# Altium schematic sheet CLK_Gen.SchDoc(CLK_Gen)
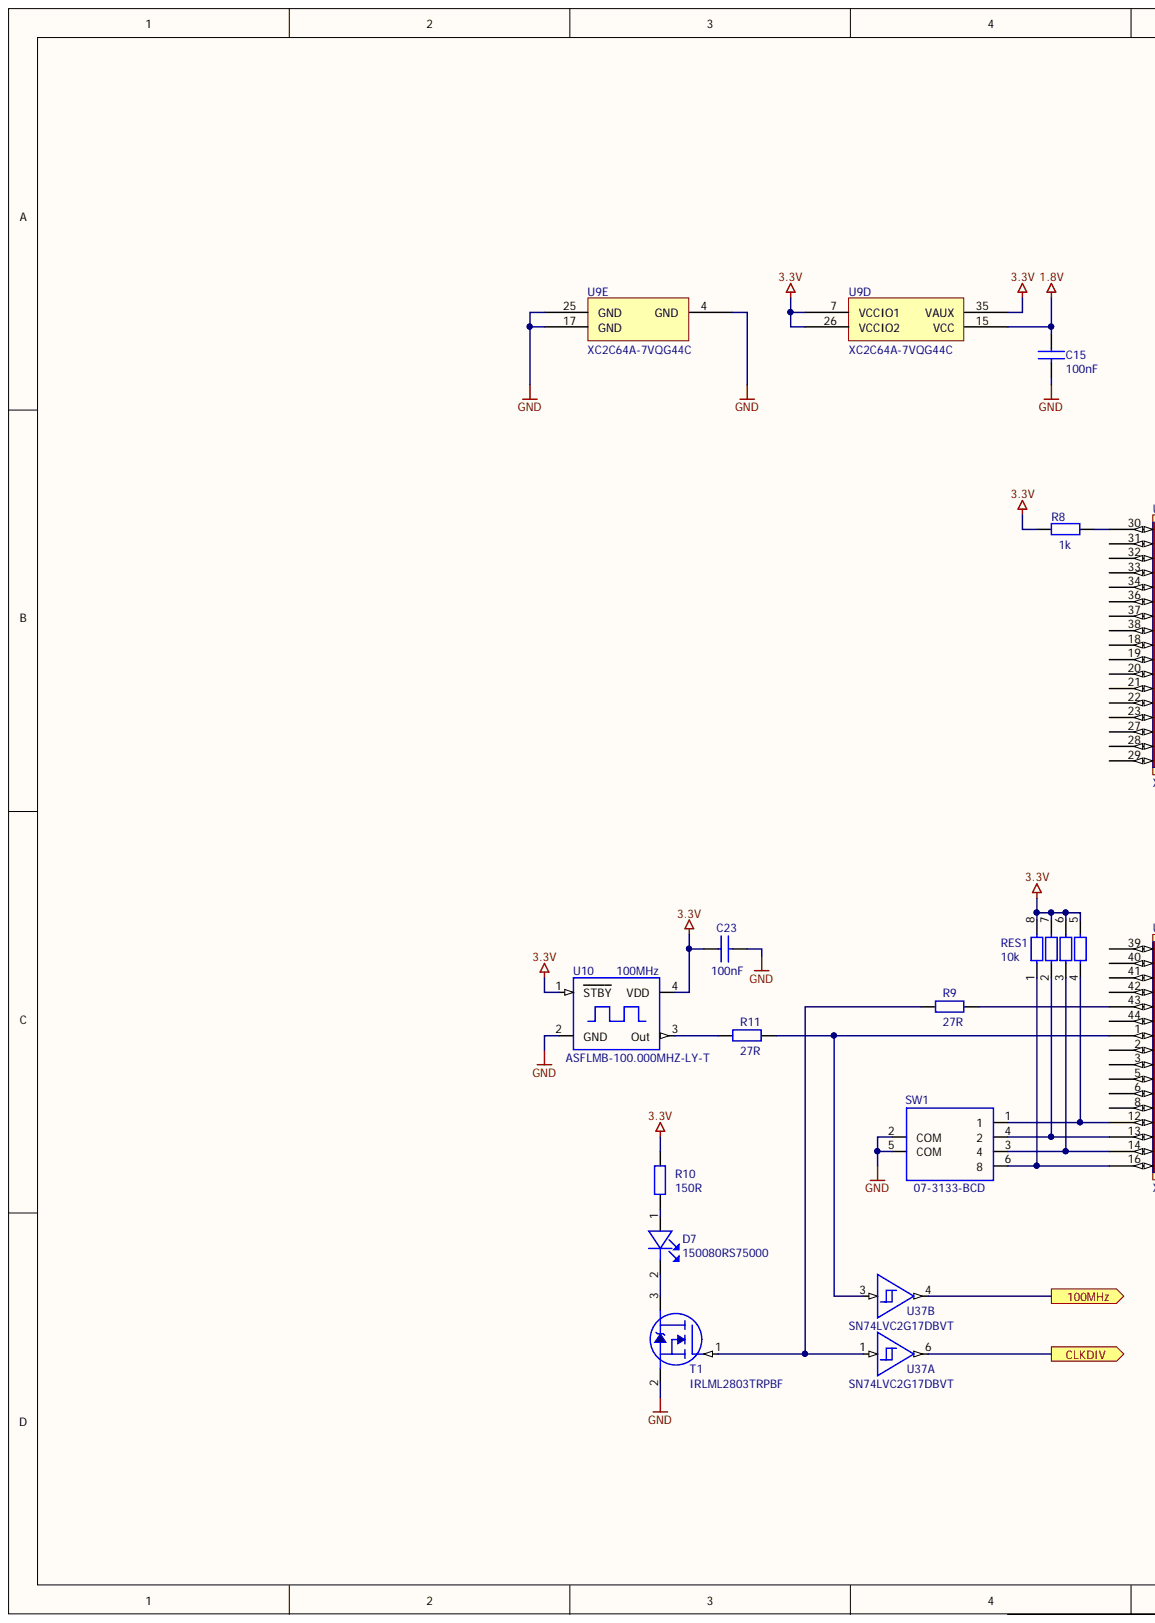
[diagram p0: CLK_Gen.SchDoc, left]
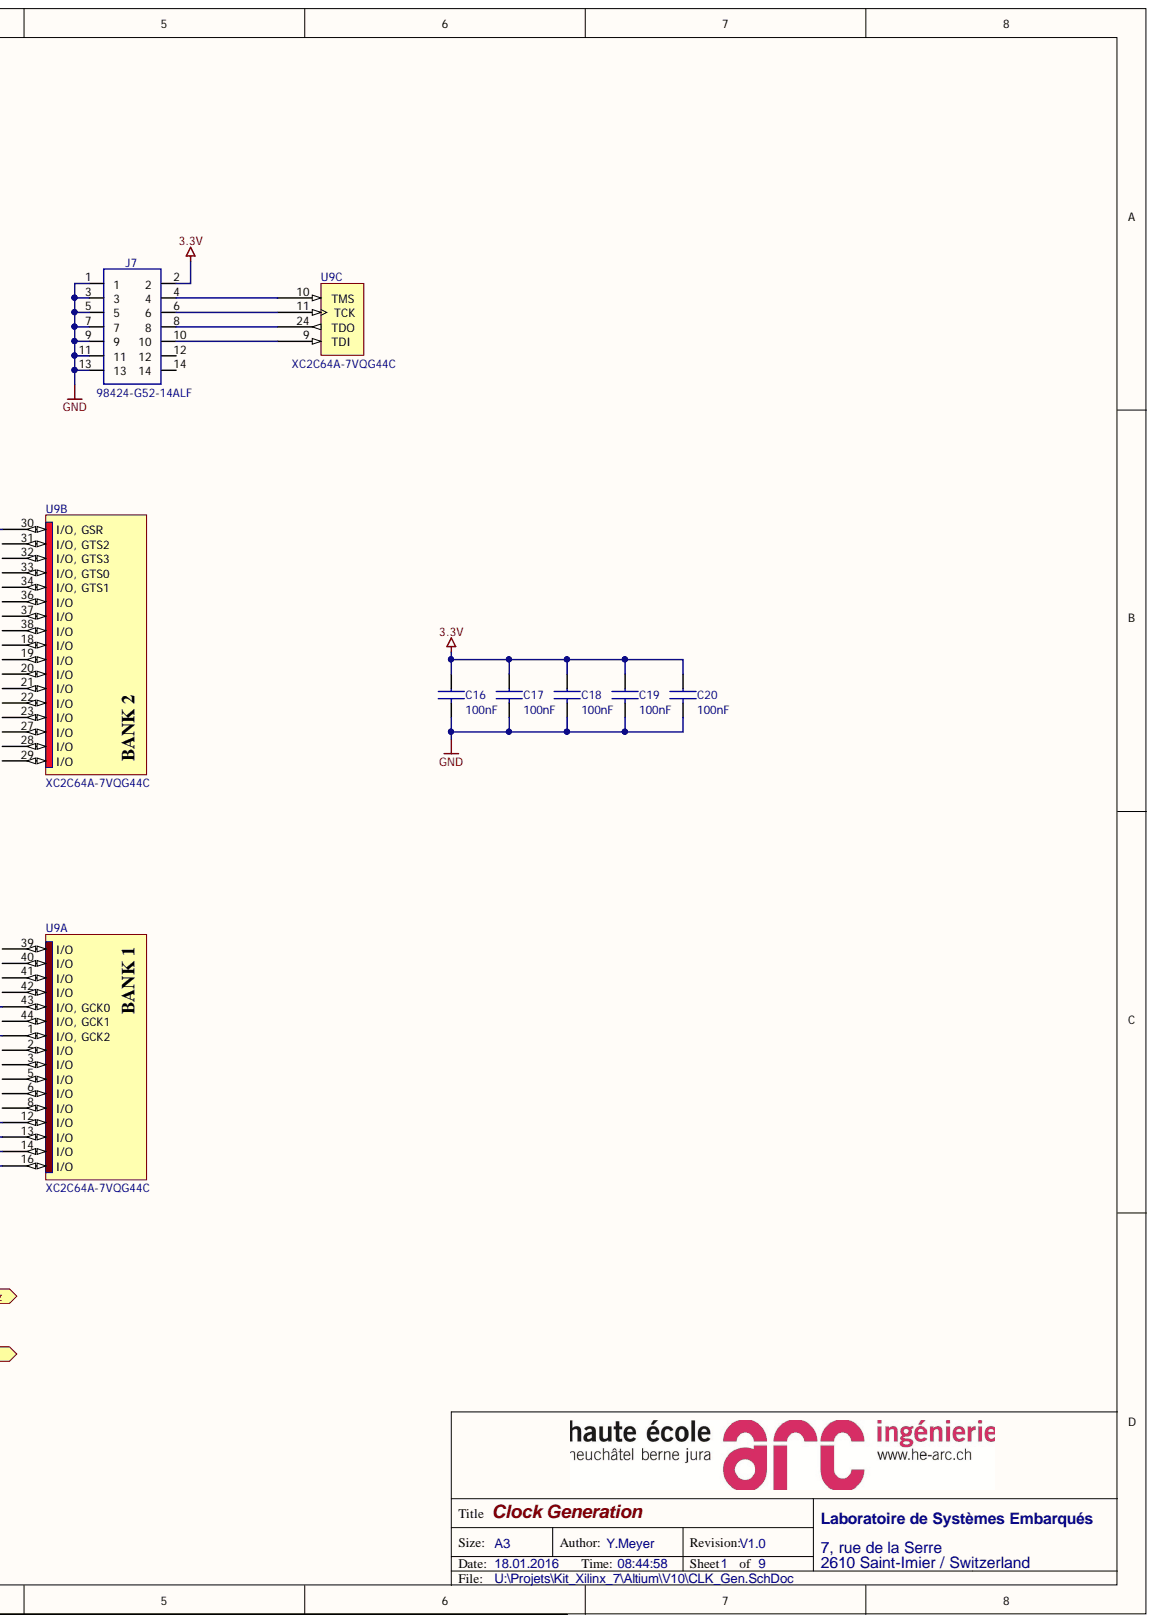
[diagram p1: CLK_Gen.SchDoc, right]

## Parts
C15  value 100nF  [060301]  (hidden comment: C0603C104Z3VAC7867)
C16  value 100nF  [060301]  (hidden comment: C0603C104Z3VAC7867)
C17  value 100nF  [060301]  (hidden comment: C0603C104Z3VAC7867)
C18  value 100nF  [060301]  (hidden comment: C0603C104Z3VAC7867)
C19  value 100nF  [060301]  (hidden comment: C0603C104Z3VAC7867)
C20  value 100nF  [060301]  (hidden comment: C0603C104Z3VAC7867)
C23  value 100nF  [060301]  (hidden comment: C0603C104Z3VAC7867)
D7  150080RS75000  [0805_Led]
J7  98424-G52-14ALF  [J207JTAG_SMD]
R8  value 1k  [060301]  (hidden comment: RC0603FR-071KL)
R9  value 27R  [060301]  (hidden comment: RC0603FR-0727RL)
R10  value 150R  [060301]  (hidden comment: RC0603FR-07150RL)
R11  value 27R  [060301]  (hidden comment: RC0603FR-0727RL)
RES1  value 10k  [4060301]  (hidden comment: YC164-JR-0710KL)
SW1  07-3133-BCD  [Commutateur_BCD]
T1  IRLML2803TRPBF  [SOT2301]
U9  XC2C64A-7VQG44C  [VQ44_N]  (parts A,B,C,D,E)
U10  ASFLMB-100.000MHZ-LY-T  value 100MHz  [OSC05]
U37  SN74LVC2G17DBVT  [SOT23601]  (parts A,B)

## Ports
100MHZ, CLKDIV

## Nets
1.8V: C15.1 U9.15(VCC)
3.3V: C16.1 C17.1 C18.1 C19.1 C20.1 C23.1 J7.2 R8.1 R10.1 RES1.5 RES1.6 RES1.7 RES1.8 U9.7(VCCIO1) U9.26(VCCIO2) U9.35(VAUX) U10.1(STBY) U10.4(VDD) U37.5
100MHZ: U37.4 ; port 100MHZ
GND: C15.2 C16.2 C17.2 C18.2 C19.2 C20.2 C23.2 J7.1 J7.3 J7.5 J7.7 J7.9 J7.11 J7.13 SW1.2(COM) SW1.5(COM) T1.2 U9.4(GND) U9.17(GND) U9.25(GND) U10.2(GND) U37.2
CLKDIV: U37.6 ; port CLKDIV
NetD7_1: D7.1 R10.2
NetD7_2: D7.2 T1.3
NetJ7_4: J7.4 U9.10(TMS)
NetJ7_6: J7.6 U9.11(TCK)
NetJ7_8: J7.8 U9.24(TDO)
NetJ7_10: J7.10 U9.9(TDI)
NetR8_2: R8.2 U9.30(I/O,_GSR)
NetR9_1: R9.1 T1.1 U37.1
NetR9_2: R9.2 U9.43(I/O,_GCK0)
NetR11_1: R11.1 U10.3(Out)
NetR11_2: R11.2 U9.1(I/O,_GCK2) U37.3
NetRES1_1: RES1.1 SW1.6 U9.16(I/O)
NetRES1_2: RES1.2 SW1.4 U9.13(I/O)
NetRES1_3: RES1.3 SW1.3 U9.14(I/O)
NetRES1_4: RES1.4 SW1.1 U9.12(I/O)

Not connected: J7 12 14; U9 2(I/O) 3(I/O) 5(I/O) 6(I/O) 8(I/O) 18(I/O) 19(I/O) 20(I/O) 21(I/O) 22(I/O) 23(I/O) 27(I/O) 28(I/O) 29(I/O) 31(I/O,_GTS2) 32(I/O,_GTS3) 33(I/O,_GTS0) 34(I/O,_GTS1) 36(I/O) 37(I/O) 38(I/O) 39(I/O) 40(I/O) 41(I/O) 42(I/O) 44(I/O,_GCK1)

## Library data of the parts
C15-C20 C23 = CAPA: CAP .10UF 25V CERAMIC Y5V 0603; Component Kind: Standard; Supplier 1: Digi-Key; Supplier Part Number 1: 399-1100-2-ND; Library Name: EISI.SchLib
D7 = LED: LED RED CLEAR 0805 SMD; Component Kind: Standard; Supplier 1: Digi-Key; Supplier Part Number 1: 732-4984-1-ND; Library Name: EISI.SchLib
J7 = CON14A: CONN HEADER 14POS 2MM STR DL SMD; Component Kind: Standard; Supplier 1: Digi-Key; Supplier Part Number 1: 609-2643-1-ND; Library Name: EISI.SchLib
R8 = RES: RES SMD 1K OHM 1% 1/10W 0603; Component Kind: Standard; Supplier 1: Digi-Key; Supplier Part Number 1: 311-1.00KHRCT-ND; Library Name: EISI.SchLib
R9 R11 = RES: RES SMD 27 OHM 1% 1/10W 0603; Component Kind: Standard; Revision: July-2002: Re-released for DXP Platform.; Supplier 1: Digi-Key; Supplier Part Number 1: 311-27.0HRCT-ND; Library Name: EISI.SchLib
R10 = RES: RES 150 OHM 1/10W 1% 0603 SMD; Component Kind: Standard; Supplier 1: Digi-Key; Supplier Part Number 1: 311-150HRTR-ND; Library Name: EISI.SchLib
RES1 = RESEAU_4R: RES ARRAY 4 RES 10K OHM 1206; Component Kind: Standard; Supplier 1: Digi-Key; Supplier Part Number 1: YC164J-10KTR-ND; Library Name: EISI.SchLib
SW1 = Commutateur_BCD: Commutateur BCD; Manufacturer 1: Elma; Component Kind: Standard; Manufacturer Part Number 1: 07-3133-BCD; Supplier 1: Elma Electronic AG; Supplier Part Number 1: 07-3133; Supplier Subtotal 1: 10.20; Supplier Unit Price 1: 510.00; Library Name: EISI.SchLib
T1 = IRLML2803: MOSFET N-CH 30V 1.2A SOT-23; Component Kind: Standard; Supplier 1: Digi-Key; Supplier Part Number 1: IRLML2803PBFTR-ND; Library Name: EISI.SchLib
U9 = XC2C64A-5VQ44C: CoolRunner-II, 1.8V, 64 Macrocell CPLD, 44-Pin VQFP, Commercial Grade; Component Kind: Standard; Library Name: Xilinx CoolRunner II.IntLib; PCB3D: XC2C64A-VQ44; Signal Integrity: XC2C64_VQ44; Supplier 1: Digi-Key; Supplier Part Number 1: 122-1410-ND
U10 = Oscillateur: OSC MEMS 100.000MHZ CMOS SMD; Component Kind: Standard; Supplier 1: Digi-Key; Supplier Part Number 1: 535-10980-1-ND; Library Name: EISI.SchLib
U37 = SN74LVC2G17: IS BUFF DL SCHMIT TRIG SOT23-6; Component Kind: Standard; Supplier 1: Digi-Key; Supplier Part Number 1: 296-26621-2-ND; Library Name: EISI.SchLib
